# Altium schematic sheet PROCESSOR.SchDoc(PROCESSOR)
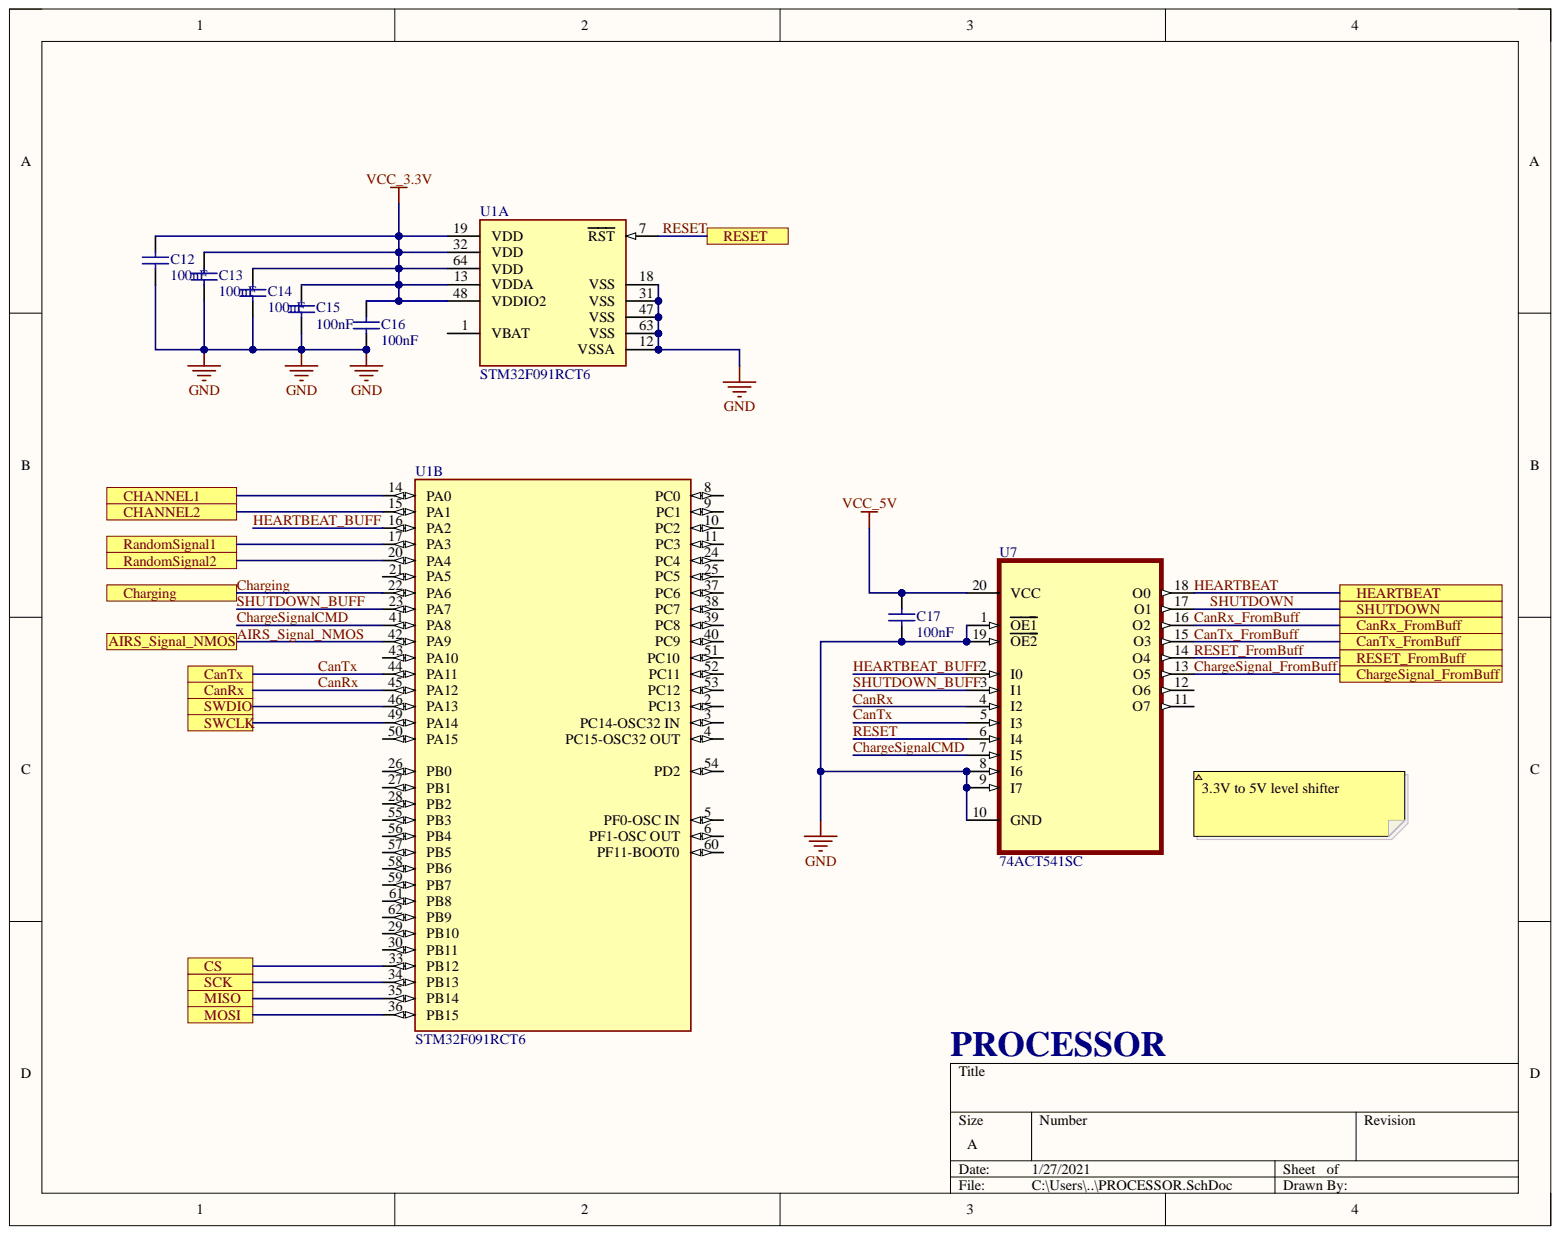
[diagram p0: PROCESSOR.SchDoc]

## Parts
C12  100nF  [Cap, 5mm TH, Ceramic]
C13  100nF  [Cap, 5mm TH, Ceramic]
C14  100nF  [Cap, 5mm TH, Ceramic]
C15  100nF  [Cap, 5mm TH, Ceramic]
C16  100nF  [Cap, 5mm TH, Ceramic]
C17  100nF  [Cap, 5mm TH, Ceramic]
U1  STM32F091RCT6  [FP-LQFP64-5W-MFG]  (parts A,B)
U7  74ACT541SC  [SOIC127P1032X265-20N]

## Ports
AIRS_Signal_NMOS, CanRx, CanRx_FromBuff, CanTx, CanTx_FromBuff, CHANNEL1, CHANNEL2, ChargeSignal_FromBuff, Charging, CS, HEARTBEAT, MISO, MOSI, RandomSignal1, RandomSignal2, RESET, RESET_FromBuff, SCK, SHUTDOWN, SWCLK, SWDIO

## Nets
GND: C12.1 C13.1 C14.1 C15.1 C16.1 C17.1 U1.12(VSSA) U1.18(VSS) U1.31(VSS) U1.47(VSS) U1.63(VSS) U7.1(OE1) U7.8(I6) U7.9(I7) U7.10(GND) U7.19(OE2)
VCC_3.3V: C12.2 C13.2 C14.2 C15.2 C16.2 U1.13(VDDA) U1.19(VDD) U1.32(VDD) U1.48(VDDIO2) U1.64(VDD)
VCC_5V: C17.2 U7.20(VCC)
AIRS_Signal_NMOS: U1.42(PA9) ; port AIRS_Signal_NMOS
CanRx: U1.45(PA12) U7.4(I2) ; port CanRx
CanRx_FromBuff: U7.16(O2) ; port CanRx_FromBuff
CanTx: U1.44(PA11) U7.5(I3) ; port CanTx
CanTx_FromBuff: U7.15(O3) ; port CanTx_FromBuff
CHANNEL1: U1.14(PA0) ; port CHANNEL1
CHANNEL2: U1.15(PA1) ; port CHANNEL2
ChargeSignal_FromBuff: U7.13(O5) ; port ChargeSignal_FromBuff
ChargeSignalCMD: U1.41(PA8) U7.7(I5)
Charging: U1.22(PA6) ; port Charging
CS: U1.33(PB12) ; port CS
HEARTBEAT: U7.18(O0) ; port HEARTBEAT
HEARTBEAT_BUFF: U1.16(PA2) U7.2(I0)
MISO: U1.35(PB14) ; port MISO
MOSI: U1.36(PB15) ; port MOSI
RandomSignal1: U1.17(PA3) ; port RandomSignal1
RandomSignal2: U1.20(PA4) ; port RandomSignal2
RESET: U1.7(RST) U7.6(I4) ; port RESET
RESET_FromBuff: U7.14(O4) ; port RESET_FromBuff
SCK: U1.34(PB13) ; port SCK
SHUTDOWN: U7.17(O1) ; port SHUTDOWN
SHUTDOWN_BUFF: U1.23(PA7) U7.3(I1)
SWCLK: U1.49(PA14) ; port SWCLK
SWDIO: U1.46(PA13) ; port SWDIO

Not connected: U1 1(VBAT) 2(PC13) 3(PC14-OSC32_IN) 4(PC15-OSC32_OUT) 5(PF0-OSC_IN) 6(PF1-OSC_OUT) 8(PC0) 9(PC1) 10(PC2) 11(PC3) 21(PA5) 24(PC4) 25(PC5) 26(PB0) 27(PB1) 28(PB2) 29(PB10) 30(PB11) 37(PC6) 38(PC7) 39(PC8) 40(PC9) 43(PA10) 50(PA15) 51(PC10) 52(PC11) 53(PC12) 54(PD2) 55(PB3) 56(PB4) 57(PB5) 58(PB6) 59(PB7) 60(PF11-BOOT0) 61(PB8) 62(PB9); U7 11(O7) 12(O6)

## Library data of the parts
C12-C17 = Cap, 5MM TH, Ceramic: Generic Cap, 5MM TH, Ceramic; TargetLibrary: RITRacing-Passives; Value: Value?; Component Kind: Standard; Library Name: Passives.IntLib; Published: 22Oct2016; Status: Active; Publisher: T.Tallo
U1 = CMP-12105-000069-1: IC MCU 32BIT 256KB FLASH 64LQFP; Manufacturer: STMicroelectronics; Core Architecture: ARM Cortex-M0; Data Bus Width: 32bits; Frequency: 48MHz; Interface: I2C,SPI,USART,CAN,LIN,IrDA,I2S,SMBus; Max Junction Temp: +105°C; Max Supply Voltage: 3.6V; Memory Size: 256KBytes; Min Supply Voltage: 2V; Nominal Supply Current: 0.9-26.9mA; Number Of A/D Converters: 1; Number Of Adc Channels: 19; Number Of I2C Channels: 2; Number Of I/Os: 52; Number Of Pwm Channels: 6; Number Of Timers/Counters: 10; Number Of Uart Channels: 8; Ram Size: 32KBytes; Standoff Height: 0.05mm; Ambient Temperature Range High: +85°C; Ambient Temperature Range Low: -40°C; Automotive: No; Category: IC; Component Kind: Standard; ComponentLink2Description: Datasheet; ComponentLink2URL: https://www.st.com/resource/en/datasheet/stm32f091rc.pdf; Device Class L1: Integrated Circuits (ICs); Device Class L2: Embedded Processors and Controllers; Device Class L3: Microcontrollers; Digikey Description: IC MCU 32BIT 256KB FLASH 64LQFP; Height: 1.6mm; Ipc Land Pattern Name: QFP50P1200X1200X160-64; Lead Free: Yes; Manufacturer Part Number: STM32F091RCT6; Memory Type: FLASH; Mouser Description: ARM Microcontrollers - MCU 16/32-BITS MICROS; Number Of Cores: 1; Number Of Spi Channels: 2; Octopart: https://octopart.com/stm32f091rct6-stmicroelectronics-51988683; Package: LQFP64-5W; RoHS: TRUE; Temperature: -40°C to +85°C; Temperature Range High: +85°C; Temperature Range Low: -40°C; Library Name: BMS_MasterboardUpdated.IntLib
U7 = 74ACT541SC: Buffer, Non-Inverting 1 Element 8 Bit per Element 3-State Output 20-SOIC; MF: ON Semiconductor; Arrow_Electronics-Purchase-URL: https://snapeda.com/shop?store=Arrow+Electronics&id=431052; Availability: Warning; Component Kind: Standard; DigiKey-Purchase-URL: https://snapeda.com/shop?store=DigiKey&id=431052; Library Name: 74ACT541SC.IntLib; Mouser-Purchase-URL: https://snapeda.com/shop?store=Mouser&id=431052; MP: 74ACT541SC; Package: SOIC-20 Fairchild Semiconductor; Price: None; SnapEDA_Link: https://www.snapeda.com/parts/74ACT541SC/ON%20Semiconductor/view-part/431052/?ref=snap
